# Altium schematic sheet NVK6114.SchDoc
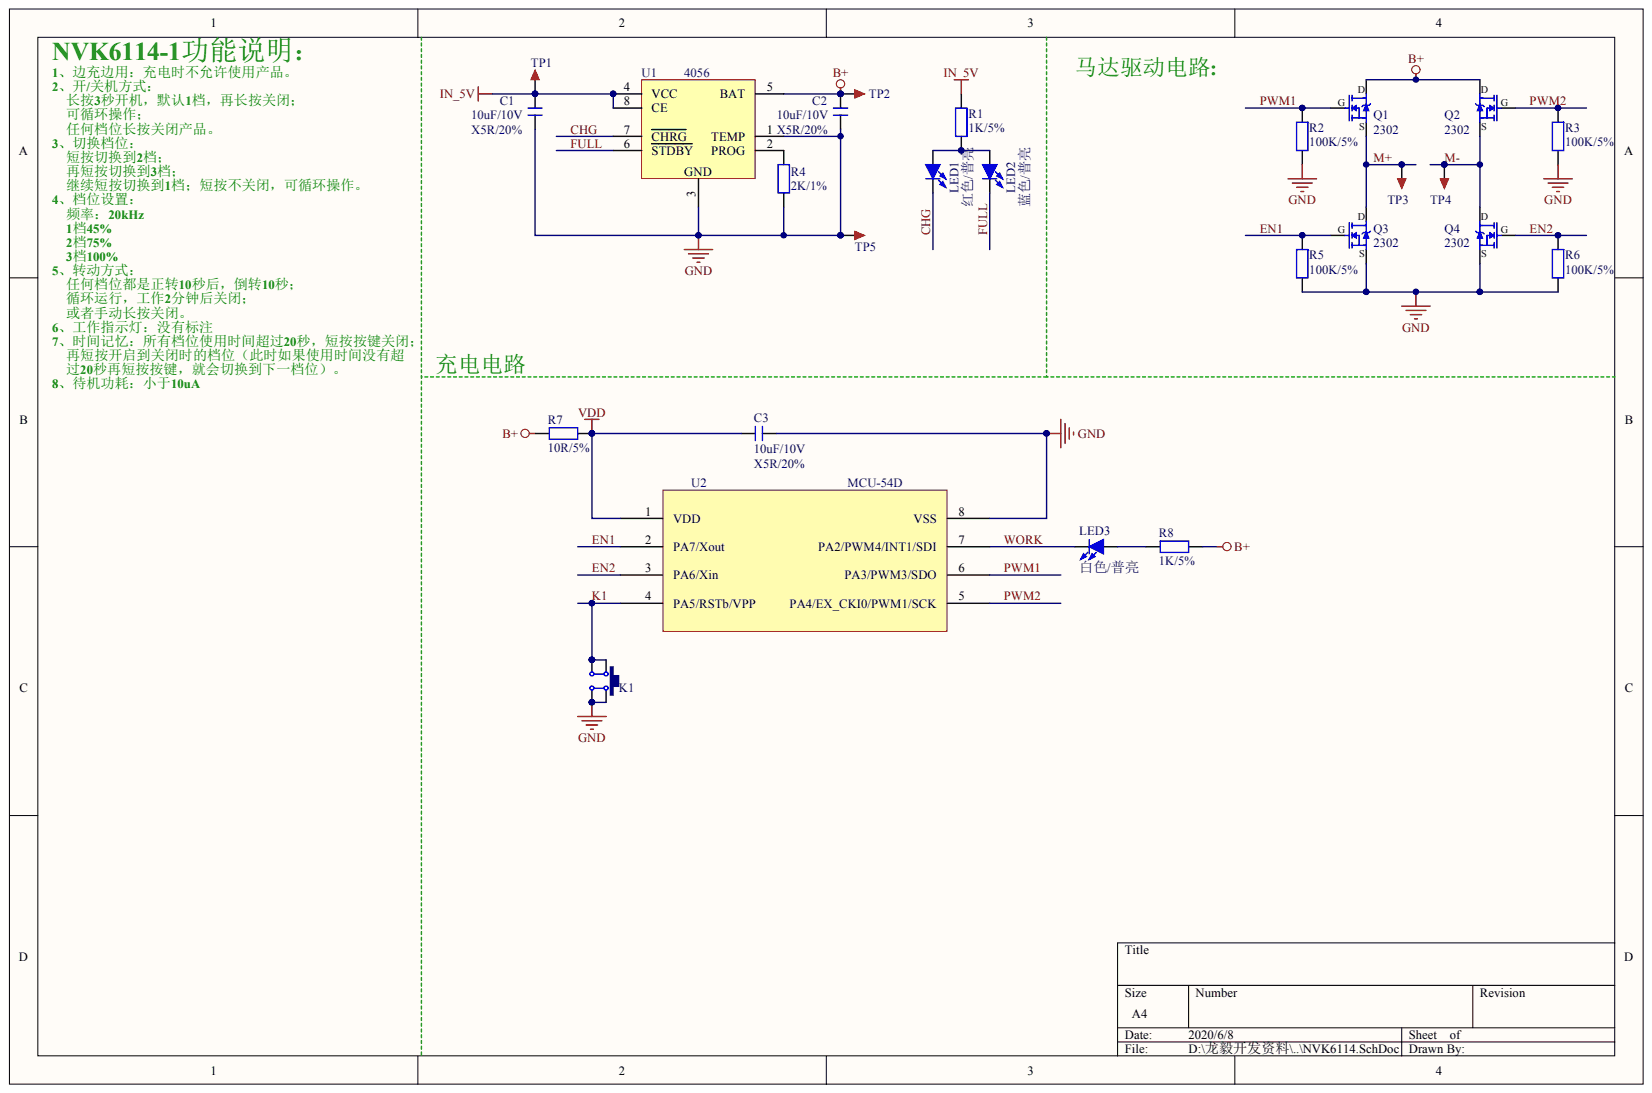
[diagram p0: NVK6114.SchDoc]

## Parts
C1  10uF/10V  材质: X5R/20%
C2  10uF/10V  材质: X5R/20%
C3  10uF/10V  材质: X5R/20%
K1  4x4x1.5  (value not drawn)
LED1  红色/普亮
LED2  蓝色/普亮
LED3  白色/普亮
Q1  2302
Q2  2302
Q3  2302
Q4  2302
R1  1K/5%
R2  100K/5%
R3  100K/5%
R4  2K/1%
R5  100K/5%
R6  100K/5%
R7  10R/5%
R8  1K/5%
TP1  TEST  (value not drawn)
TP2  TEST  (value not drawn)
TP3  TEST  (value not drawn)
TP4  TEST  (value not drawn)
TP5  TEST  (value not drawn)
U1  4056
U2  MCU-54D

## Nets
B+: C2.2 Q1.3 Q2.3 R7.2 R8.1 TP2.1 U1.5(BAT)
GND: C1.1 C2.1 C3.1 K1.2 K1.3 Q3.2 Q4.2 R2.1 R3.1 R4.1 R5.1 R6.1 TP5.1 U1.1(TEMP) U1.3 U2.8(VSS)
IN_5V: C1.2 R1.1 TP1.1 U1.4(VCC) U1.8(CE)
VDD: C3.2 R7.1 U2.1(VDD)
CHG: LED1.2 U1.7(CHRG)
EN1: Q3.1 R5.2 U2.2(PA7/Xout)
EN2: Q4.1 R6.2 U2.3(PA6/Xin)
FULL: LED2.2 U1.6(STDBY)
K1: K1.1 K1.4 U2.4(PA5/RSTb/VPP)
M+: Q1.2 Q3.3 TP3.1
M-: Q2.2 Q4.3 TP4.1
PWM1: Q1.1 R2.2 U2.6(PA3/PWM3/SDO)
PWM2: Q2.1 R3.2 U2.5(PA4/EX_CKI0/PWM1/SCK)
WORK: LED3.2 U2.7(PA2/PWM4/INT1/SDI)
NetLED1_1: LED1.1 LED2.1 R1.2
NetLED3_1: LED3.1 R8.2
NetR4_2: R4.2 U1.2(PROG)

## Library data of the parts
C1-C3 = (no symbol name): BOM名称: 无极性贴片电容; 版权归属: 源创客; 学习资源: www.ooocke.com
K1 = (no symbol name): BOM名称: 防水轻触开关; 版权归属: 源创客; 学习资源: www.ooocke.com
LED1-LED3 = (no symbol name): BOM名称: 贴片LED; 版权归属: 源创客; 学习资源: www.ooocke.com
Q1-Q4 = (no symbol name): BOM名称: 场效应管; 版权归属: 源创客; 描述: N沟道 30V/4.2A; 学习资源: www.ooocke.com
R1-R8 = (no symbol name): BOM名称: 贴片电阻; 版权归属: 源创客; 学习资源: www.ooocke.com
TP1-TP5 = (no symbol name): BOM名称: 测试点; 版权归属: 源创客; 学习资源: www.ooocke.com
U1 = (no symbol name): BOM名称: 锂电池充电管理
